# Altium schematic sheet MOTOR_Cu.SchDoc(MOTOR_Cu)
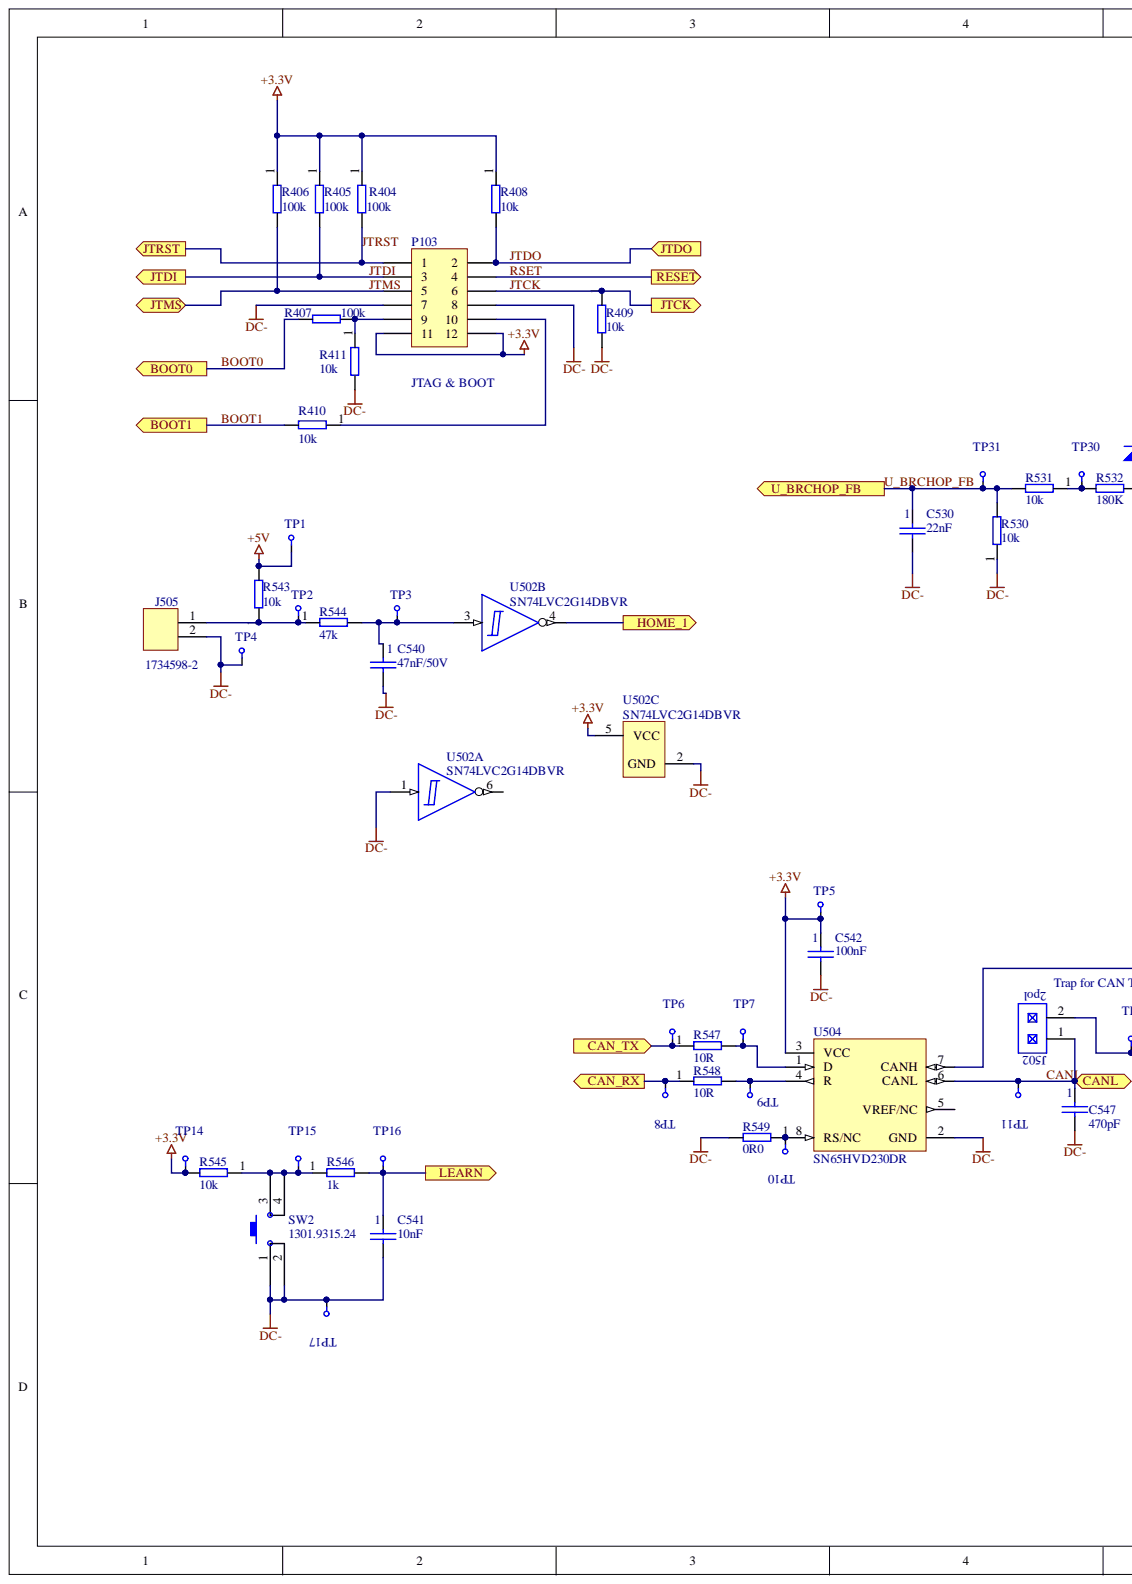
[diagram p0: MOTOR_Cu.SchDoc, left]
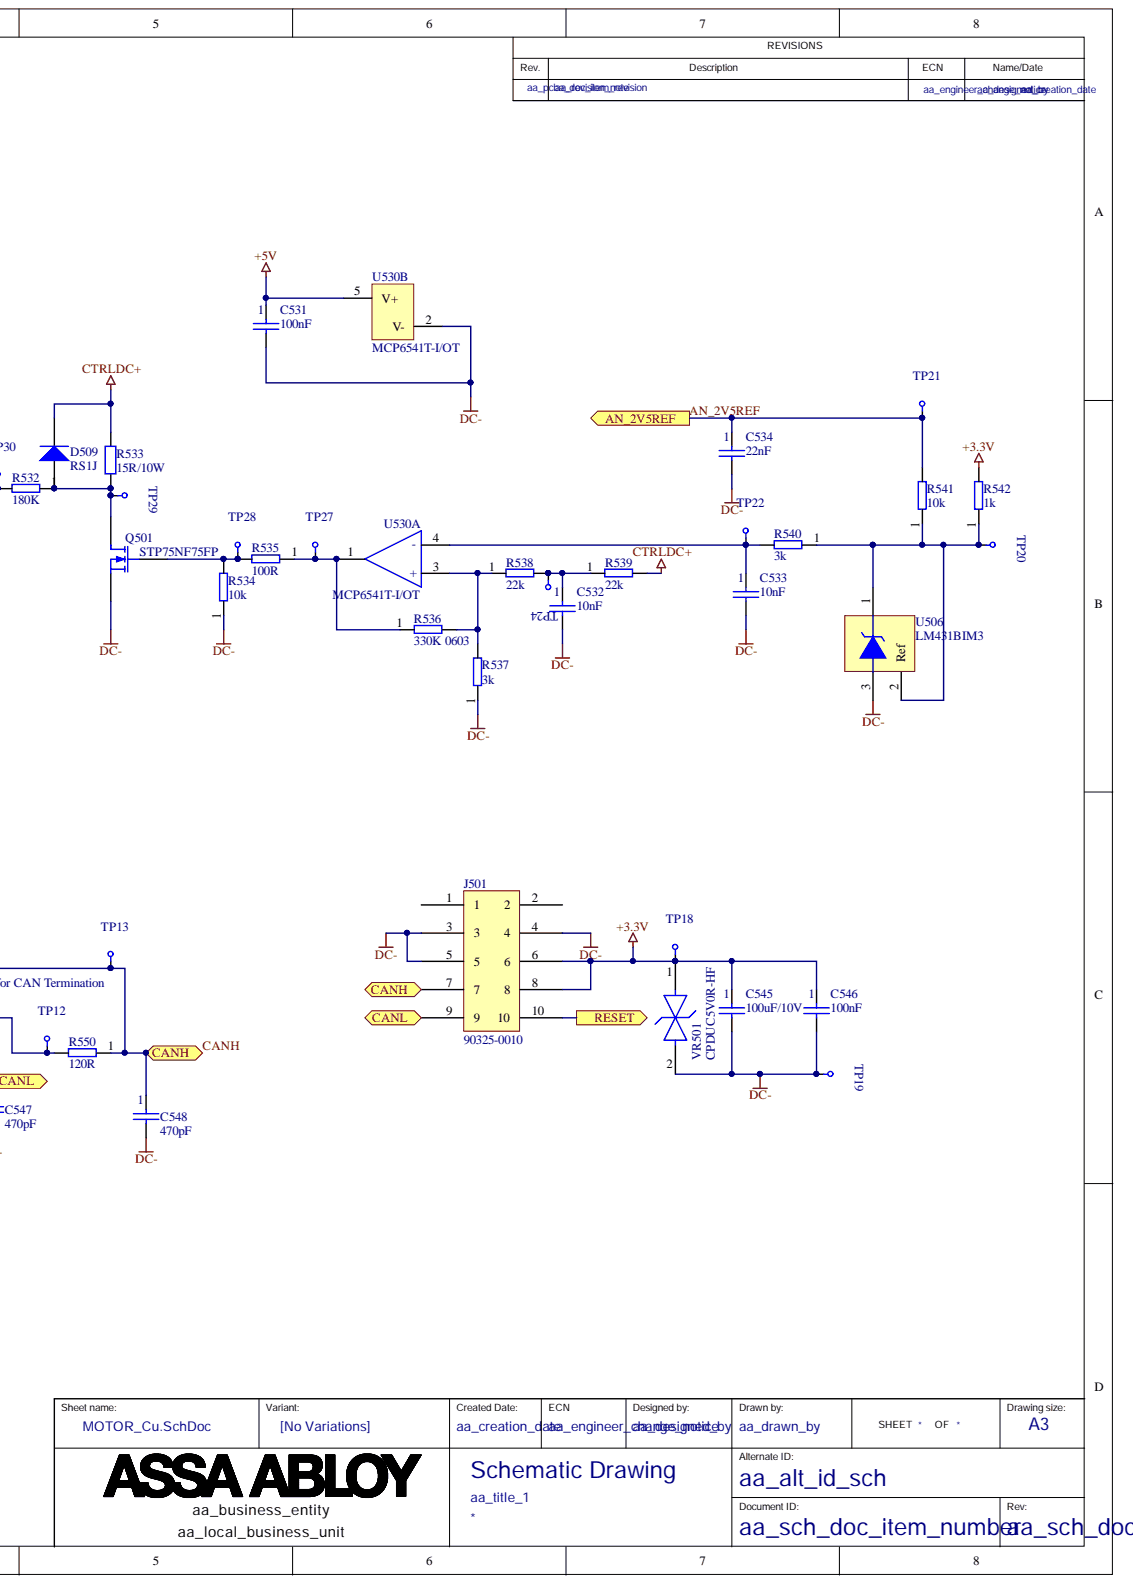
[diagram p1: MOTOR_Cu.SchDoc, right]

## Parts
C530  22nF  [CAPC1608X08N]
C531  100nF  [CAPC1608X08N]
C532  10nF  [CAPC1608X08N]
C533  10nF  [CAPC1608X08N]
C534  22nF  [CAPC1608X08N]
C540  47nF/50V  [CAPC1608X10N]
C541  10nF  [CAPC1608X08N]
C542  100nF  [CAPC1608X08N]
C545  100uF/10V  [CAPC3216X18N]
C546  100nF  [CAPC1608X08N]
C547  470pF  [CAPC1608X08N]
C548  470pF  [CAPC1608X08N]
D509  RS1J  [SMA-S1G]
J501  90325-0010  [MOLEX_90325-0010]
J502  2pol  [HDRV2W64P254_2X1_508X248H838]
J505  1734598-2  [CON1X2-MOLEX-0530470210]
P103  JTAG & BOOT  [TP_6X2]
Q501  STP75NF75FP  [MOSFET_STP75NF75FP]
R404  100k  [RESC1608X05N]
R405  100k  [RESC1608X05N]
R406  100k  [RESC1608X05N]
R407  100k  [RESC1608X05N]
R408  10k  [RESC1608X05N]
R409  10k  [RESC1608X05N]
R410  10k  [RESC1608X05N]
R411  10k  [RESC1608X05N]
R530  10k  [RESC1608X05N]
R531  10k  [RESC1608X05N]
R532  180K  [0603R/H]
R533  15R/10W  [RES_POWER_36x16x11.5]
R534  10k  [RESC1608X05N]
R535  100R  [RESC1608X05N]
R536  330K 0603  [0603R/H]
R537  3k  [RESC1608X05N]
R538  22k  [RESC1608X05N]
R539  22k  [RESC1608X05N]
R540  3k  [RESC1608X05N]
R541  10k  [RESC1608X05N]
R542  1k  [RESC1608X05N]
R543  10k  [RESC1608X05N]
R544  47k  [RESC1608X05N]
R545  10k  [RESC1608X05N]
R546  1k  [RESC1608X05N]
R547  10R  [RESC1608X05N]
R548  10R  [RESC1608X05N]
R549  0R0  [RESC1608X05N]
R550  120R  [RESC2012X07N]
SW2  1301.9315.24  [SW_SCHURTER_1301.9315.24]
TP1  Testpoint SMD  [PCB_PARTS_TESTPOINT100_SMD]  (value not drawn)  (no BOM)
TP2  Testpoint SMD  [PCB_PARTS_TESTPOINT100_SMD]  (value not drawn)  (no BOM)
TP3  Testpoint SMD  [PCB_PARTS_TESTPOINT100_SMD]  (value not drawn)  (no BOM)
TP4  Testpoint SMD  [PCB_PARTS_TESTPOINT100_SMD]  (value not drawn)  (no BOM)
TP5  Testpoint SMD  [PCB_PARTS_TESTPOINT100_SMD]  (value not drawn)  (no BOM)
TP6  Testpoint SMD  [PCB_PARTS_TESTPOINT100_SMD]  (value not drawn)  (no BOM)
TP7  Testpoint SMD  [PCB_PARTS_TESTPOINT100_SMD]  (value not drawn)  (no BOM)
TP8  Testpoint SMD  [PCB_PARTS_TESTPOINT100_SMD]  (value not drawn)  (no BOM)
TP9  Testpoint SMD  [PCB_PARTS_TESTPOINT100_SMD]  (value not drawn)  (no BOM)
TP10  Testpoint SMD  [PCB_PARTS_TESTPOINT100_SMD]  (value not drawn)  (no BOM)
TP11  Testpoint SMD  [PCB_PARTS_TESTPOINT100_SMD]  (value not drawn)  (no BOM)
TP12  Testpoint SMD  [PCB_PARTS_TESTPOINT100_SMD]  (value not drawn)  (no BOM)
TP13  Testpoint SMD  [PCB_PARTS_TESTPOINT100_SMD]  (value not drawn)  (no BOM)
TP14  Testpoint SMD  [PCB_PARTS_TESTPOINT100_SMD]  (value not drawn)  (no BOM)
TP15  Testpoint SMD  [PCB_PARTS_TESTPOINT100_SMD]  (value not drawn)  (no BOM)
TP16  Testpoint SMD  [PCB_PARTS_TESTPOINT100_SMD]  (value not drawn)  (no BOM)
TP17  Testpoint SMD  [PCB_PARTS_TESTPOINT100_SMD]  (value not drawn)  (no BOM)
TP18  Testpoint SMD  [PCB_PARTS_TESTPOINT100_SMD]  (value not drawn)  (no BOM)
TP19  Testpoint SMD  [PCB_PARTS_TESTPOINT100_SMD]  (value not drawn)  (no BOM)
TP20  Testpoint SMD  [PCB_PARTS_TESTPOINT100_SMD]  (value not drawn)  (no BOM)
TP21  Testpoint SMD  [PCB_PARTS_TESTPOINT100_SMD]  (value not drawn)  (no BOM)
TP22  Testpoint SMD  [PCB_PARTS_TESTPOINT100_SMD]  (value not drawn)  (no BOM)
TP24  Testpoint SMD  [PCB_PARTS_TESTPOINT100_SMD]  (value not drawn)  (no BOM)
TP27  Testpoint SMD  [PCB_PARTS_TESTPOINT100_SMD]  (value not drawn)  (no BOM)
TP28  Testpoint SMD  [PCB_PARTS_TESTPOINT100_SMD]  (value not drawn)  (no BOM)
TP29  Testpoint SMD  [PCB_PARTS_TESTPOINT100_SMD]  (value not drawn)  (no BOM)
TP30  Testpoint SMD  [PCB_PARTS_TESTPOINT100_SMD]  (value not drawn)  (no BOM)
TP31  Testpoint SMD  [PCB_PARTS_TESTPOINT100_SMD]  (value not drawn)  (no BOM)
U502  SN74LVC2G14DBVR  [SOT95P280X145-6N]  (parts A,B,C)
U504  SN65HVD230DR  [SOIC127P600X175-8N]
U506  LM431BIM3  [SOT95P251X112-3N]
U530  MCP6541T-I/OT  [SOT95P280X145-5N]  (parts A,B)
VR501  CPDUC5V0R-HF  [DFN110P170X55-2N]

## Ports
AN_2V5REF, BOOT0, BOOT1, CAN_RX, CAN_TX, CANH, CANL, HOME_1, JTCK, JTDI, JTDO, JTMS, JTRST, LEARN, RESET, U_BRCHOP_FB

## Nets
+3.3V: C542.1 C545.1 C546.1 J501.6 J501.8 P103.11 P103.12 R404.1 R405.1 R406.1 R408.1 R542.2 R545.2 TP5.1 TP14.1 TP18.1 U502.5(VCC) U504.3(VCC) VR501.1
+5V: C531.1 R543.2 TP1.1 U530.5(V+)
CTRLDC+: D509.2 R533.1 R539.2
DC-: C530.2 C531.2 C532.2 C533.2 C534.2 C540.2 C541.2 C542.2 C545.2 C546.2 C547.2 C548.2 J501.3 J501.4 J501.5 J505.2 P103.7 P103.8 Q501.3 R409.2 R411.2 R530.1 R534.1 R537.1 R549.2 SW2.1 SW2.2 TP4.1 TP17.1 TP19.1 U502.1 U502.2(GND) U504.2(GND) U506.3 U530.2(V-) VR501.2
AN_2V5REF: C534.1 R541.2 TP21.1 ; port AN_2V5REF
BOOT0: R407.1 ; port BOOT0
BOOT1: R410.2 ; port BOOT1
CAN_RX: R548.1 TP8.1 ; port CAN_RX
CAN_TX: R547.1 TP6.1 ; port CAN_TX
CANH: C548.1 J501.7 R550.1 TP13.1 U504.7(CANH) ; port CANH
CANL: C547.1 J501.9 J502.1 TP11.1 U504.6(CANL) ; port CANL
HOME_1: U502.4 ; port HOME_1
JTCK: P103.6 R409.1 ; port JTCK
JTDI: P103.3 R405.2 ; port JTDI
JTDO: P103.2 R408.2 ; port JTDO
JTMS: P103.5 R406.2 ; port JTMS
JTRST: P103.1 R404.2 ; port JTRST
LEARN: C541.1 R546.2 TP16.1 ; port LEARN
RSET: J501.10 P103.4 ; port RESET
U_BRCHOP_FB: C530.1 R530.2 R531.2 TP31.1 ; port U_BRCHOP_FB
NetC532_1: C532.1 R538.2 R539.1 TP24.1
NetC533_1: C533.1 R540.2 TP22.1 U530.4(-)
NetC540_1: C540.1 R544.2 TP3.1 U502.3
NetD509_1: D509.1 Q501.2 R532.1 R533.2 TP29.1
NetJ502_2: J502.2 R550.2 TP12.1
NetJ505_1: J505.1 R543.1 R544.1 TP2.1
NetP103_9: P103.9 R407.2 R411.1
NetP103_10: P103.10 R410.1
NetQ501_1: Q501.1 R534.2 R535.2 TP28.1
NetR531_1: R531.1 R532.2 TP30.1
NetR535_1: R535.1 R536.1 TP27.1 U530.1
NetR536_2: R536.2 R537.2 R538.1 U530.3(+)
NetR540_1: R540.1 R541.1 R542.1 TP20.1 U506.1 U506.2
NetR545_1: R545.1 R546.1 SW2.3 SW2.4 TP15.1
NetR547_2: R547.2 TP7.1 U504.1(D)
NetR548_2: R548.2 TP9.1 U504.4(R)
NetR549_1: R549.1 TP10.1 U504.8(RS/NC)

Not connected: J501 1 2; U502 6; U504 5(VREF/NC)

## Library data of the parts
C530 C534 = 715500: Capacitor; APPROVED_MPN_04: 2238586_5641 (RoHS); APPROVED_MPN_03: GRM188R71H223KA01_ (RoHS); APPROVED_MPN_02: C0603C223K5RAC (RoHS); APPROVED_MPN_01: 06035C223KAT2A (RoHS); APPROVED_MANUFACTURER_04: Yageo Phycomp.; APPROVED_MANUFACTURER_03: MURATA; APPROVED_MANUFACTURER_02: KEMET; APPROVED_MANUFACTURER_01: AVX; PHYSICALPACKAGE: EIA0603; MOUNTEDTYPE: SMD; Database Table Name: Capacitors_Ceramic; VALUE: 22nF; TOLERANCE: -; PROTOTYPE_MPN_01: -; PROTOTYPE_MANUFACTURER_01: -; POWERRATING: -; PARTNUMBER: 715500; PARTNAME: Capacitor; Library Name: AltiumLib_Database.DbLib; Component Kind: Standard; APPROVED_MPN_08: -; APPROVED_MPN_07: -; APPROVED_MPN_06: -; APPROVED_MPN_05: -; APPROVED_MANUFACTURER_08: -; APPROVED_MANUFACTURER_07: -; APPROVED_MANUFACTURER_06: -; APPROVED_MANUFACTURER_05: -
C531 C546 = 715219: Capacitor; APPROVED_MPN_05: 223878615649 ,X7R, 0603, 10%; APPROVED_MPN_04: CC0603KRX7R7BB104; APPROVED_MPN_03: 0603YC104KAT ,X7R,0603,10%; APPROVED_MPN_02: GRM188R71C104KA01; APPROVED_MPN_01: CL10B104KO8NNN; APPROVED_MANUFACTURER_05: Yageo Phy; APPROVED_MANUFACTURER_04: YAGEO; APPROVED_MANUFACTURER_03: AVX KYOCERA; APPROVED_MANUFACTURER_02: MURATA; APPROVED_MANUFACTURER_01: SAMSUNG; PHYSICALPACKAGE: EIA0603; MOUNTEDTYPE: SMD; Database Table Name: Capacitors_Ceramic; VALUE: 100nF; TOLERANCE: -; PROTOTYPE_MPN_01: -; PROTOTYPE_MANUFACTURER_01: -; POWERRATING: -; PARTNUMBER: 715219; PARTNAME: Capacitor; Library Name: AltiumLib_Database.DbLib; Component Kind: Standard; APPROVED_MPN_08: -; APPROVED_MPN_07: -; APPROVED_MPN_06: -; APPROVED_MANUFACTURER_08: -; APPROVED_MANUFACTURER_07: -; APPROVED_MANUFACTURER_06: -
C532 C533 C541 = 1700542: Capacitor; APPROVED_MPN_01: C1608CH1E103J  RoHS; APPROVED_MANUFACTURER_01: TDK; PHYSICALPACKAGE: EIA0603; MOUNTEDTYPE: SMD; Database Table Name: Capacitors_Ceramic; VALUE: 10nF; TOLERANCE: -; PROTOTYPE_MPN_01: -; PROTOTYPE_MANUFACTURER_01: -; POWERRATING: -; PARTNUMBER: 1700542; PARTNAME: Capacitor; Library Name: AltiumLib_Database.DbLib; Component Kind: Standard; APPROVED_MPN_08: -; APPROVED_MPN_07: -; APPROVED_MPN_06: -; APPROVED_MPN_05: -; APPROVED_MPN_04: -; APPROVED_MPN_03: -; APPROVED_MPN_02: -; APPROVED_MANUFACTURER_08: -; APPROVED_MANUFACTURER_07: -; APPROVED_MANUFACTURER_06: -; APPROVED_MANUFACTURER_05: -; APPROVED_MANUFACTURER_04: -; APPROVED_MANUFACTURER_03: -; APPROVED_MANUFACTURER_02: -
C540 = 1700539: Capacitor; APPROVED_MPN_02: CC0603KRX7R9BB473; APPROVED_MPN_01: C1608X7R1H473K080AA; APPROVED_MANUFACTURER_02: YAGEO; APPROVED_MANUFACTURER_01: TDK; TOLERANCE: 10%; PHYSICALPACKAGE: EIA0603; MOUNTEDTYPE: SMD; Database Table Name: Capacitors_Ceramic; VALUE: 47nF/50V; PROTOTYPE_MPN_01: -; PROTOTYPE_MANUFACTURER_01: -; POWERRATING: -; PARTNUMBER: 1700539; PARTNAME: Capacitor; Library Name: AltiumLib_Database.DbLib; Component Kind: Standard; APPROVED_MPN_08: -; APPROVED_MPN_07: -; APPROVED_MPN_06: -; APPROVED_MPN_05: -; APPROVED_MPN_04: -; APPROVED_MPN_03: -; APPROVED_MANUFACTURER_08: -; APPROVED_MANUFACTURER_07: -; APPROVED_MANUFACTURER_06: -; APPROVED_MANUFACTURER_05: -; APPROVED_MANUFACTURER_04: -; APPROVED_MANUFACTURER_03: -
C542 = 1700540: Capacitor; APPROVED_MPN_06: 0603B104K500CT; APPROVED_MPN_05: CC0603K_9104; APPROVED_MPN_04: C0603C104K5RAC; APPROVED_MPN_03: 06035C104KAT_A; APPROVED_MPN_02: GRM188R71H104K; APPROVED_MPN_01: C1608X7R1H104K; APPROVED_MANUFACTURER_06: WALSIN; APPROVED_MANUFACTURER_05: YAGEO; APPROVED_MANUFACTURER_04: KEMET; APPROVED_MANUFACTURER_03: AVX; APPROVED_MANUFACTURER_02: MURATA; APPROVED_MANUFACTURER_01: TDK; PHYSICALPACKAGE: EIA0603; MOUNTEDTYPE: SMD; Database Table Name: Capacitors_Ceramic; VALUE: 100nF; TOLERANCE: -; PROTOTYPE_MPN_01: -; PROTOTYPE_MANUFACTURER_01: -; POWERRATING: -; PARTNUMBER: 1700540; PARTNAME: Capacitor; Library Name: AltiumLib_Database.DbLib; Component Kind: Standard; APPROVED_MPN_08: -; APPROVED_MPN_07: -; APPROVED_MANUFACTURER_08: -; APPROVED_MANUFACTURER_07: -
C545 = 1703746: Capacitor; APPROVED_MPN_02: C3216X5R1A107M160AC; APPROVED_MPN_01: GRM31CR61A107ME05L; APPROVED_MANUFACTURER_02: TDK; APPROVED_MANUFACTURER_01: Murata; TOLERANCE: 20%; PHYSICALPACKAGE: EIA1206; MOUNTEDTYPE: SMD; Database Table Name: Capacitors_Ceramic; VALUE: 100uF/10V; PROTOTYPE_MPN_01: -; PROTOTYPE_MANUFACTURER_01: -; POWERRATING: -; PARTNUMBER: 1703746; PARTNAME: Capacitor; Library Name: AltiumLib_Database.DbLib; Component Kind: Standard; APPROVED_MPN_08: -; APPROVED_MPN_07: -; APPROVED_MPN_06: -; APPROVED_MPN_05: -; APPROVED_MPN_04: -; APPROVED_MPN_03: -; APPROVED_MANUFACTURER_08: -; APPROVED_MANUFACTURER_07: -; APPROVED_MANUFACTURER_06: -; APPROVED_MANUFACTURER_05: -; APPROVED_MANUFACTURER_04: -; APPROVED_MANUFACTURER_03: -
C547 C548 = 1700536: Capacitor; APPROVED_MPN_01: C1608JB1H471K  RoHS; APPROVED_MANUFACTURER_01: TDK; PHYSICALPACKAGE: EIA0603; MOUNTEDTYPE: SMD; Database Table Name: Capacitors_Ceramic; VALUE: 470pF; TOLERANCE: -; PROTOTYPE_MPN_01: -; PROTOTYPE_MANUFACTURER_01: -; POWERRATING: -; PARTNUMBER: 1700536; PARTNAME: Capacitor; Library Name: AltiumLib_Database.DbLib; Component Kind: Standard; APPROVED_MPN_08: -; APPROVED_MPN_07: -; APPROVED_MPN_06: -; APPROVED_MPN_05: -; APPROVED_MPN_04: -; APPROVED_MPN_03: -; APPROVED_MPN_02: -; APPROVED_MANUFACTURER_08: -; APPROVED_MANUFACTURER_07: -; APPROVED_MANUFACTURER_06: -; APPROVED_MANUFACTURER_05: -; APPROVED_MANUFACTURER_04: -; APPROVED_MANUFACTURER_03: -; APPROVED_MANUFACTURER_02: -
D509 = Diode: Default Diode; MPN: Vishay, Fairchild, Taiwan Semiconductor/ RS1J (RoHS); Article: 1700744-2.0; Simulation: DIODE; Signal Integrity: Diode; Published: 8-Jun-2000; PackageVersion: Sep-1996; PackageReference: SMC; PackageDescription: DO-214-AB/SMC; 2 C-Bend Leads; Body 7.9 x 5.9 mm, inc. leads (LxW); Library Name: Miscellaneous Devices.IntLib; LatestRevisionNote: Re-released for DXP Platform.; LatestRevisionDate: 17-Jul-2002; Component Kind: Standard; Code_JEDEC: DO-214-AB; Publisher: Altium Limited
J501 = 1703717: 10 pins connector; APPROVED_MANUFACTURER_01: Molex; PHYSICALPACKAGE: 2x5pol 1.27mm; MOUNTEDTYPE: THM; Database Table Name: CONNECTORS; VALUE: 90325-0010; TOLERANCE: -; PROTOTYPE_MPN_01: -; PROTOTYPE_MANUFACTURER_01: -; POWERRATING: -; PARTNUMBER: 1703717; PARTNAME: 90325-0010; Library Name: AltiumLib_Database.DbLib; Component Kind: Standard; APPROVED_MPN_08: -; APPROVED_MPN_07: -; APPROVED_MPN_06: -; APPROVED_MPN_05: -; APPROVED_MPN_04: -; APPROVED_MPN_03: -; APPROVED_MPN_02: -; APPROVED_MPN_01: 90325-0010; APPROVED_MANUFACTURER_08: -; APPROVED_MANUFACTURER_07: -; APPROVED_MANUFACTURER_06: -; APPROVED_MANUFACTURER_05: -; APPROVED_MANUFACTURER_04: -; APPROVED_MANUFACTURER_03: -; APPROVED_MANUFACTURER_02: -
J502 = 1700830: 2pol connector; APPROVED_MPN_02: 1125-1102GOS11201; APPROVED_MPN_01: 90120-0922; APPROVED_MANUFACTURER_02: WCON; APPROVED_MANUFACTURER_01: Molex; PHYSICALPACKAGE: 2pol 2.54mm; MOUNTEDTYPE: THM; Database Table Name: CONNECTORS; VALUE: 2pol; TOLERANCE: -; PROTOTYPE_MPN_01: -; PROTOTYPE_MANUFACTURER_01: -; POWERRATING: -; PARTNUMBER: 1700830; PARTNAME: 2pol; Library Name: AltiumLib_Database.DbLib; Component Kind: Standard; APPROVED_MPN_08: -; APPROVED_MPN_07: -; APPROVED_MPN_06: -; APPROVED_MPN_05: -; APPROVED_MPN_04: -; APPROVED_MPN_03: -; APPROVED_MANUFACTURER_08: -; APPROVED_MANUFACTURER_07: -; APPROVED_MANUFACTURER_06: -; APPROVED_MANUFACTURER_05: -; APPROVED_MANUFACTURER_04: -; APPROVED_MANUFACTURER_03: -
J505 = 1701462: 2-pol connector; APPROVED_MPN_02: 1251SM-02; APPROVED_MANUFACTURER_02: NELTRON; APPROVED_MANUFACTURER_01: Tyco; PHYSICALPACKAGE: 1x2pol 1.25mm; MOUNTEDTYPE: THM; Database Table Name: CONNECTORS; VALUE: 1734598-2; TOLERANCE: -; PROTOTYPE_MPN_01: -; PROTOTYPE_MANUFACTURER_01: -; POWERRATING: -; PARTNUMBER: 1701462; PARTNAME: 1734598-2; Library Name: AltiumLib_Database.DbLib; Component Kind: Standard; APPROVED_MPN_08: -; APPROVED_MPN_07: -; APPROVED_MPN_06: -; APPROVED_MPN_05: -; APPROVED_MPN_04: -; APPROVED_MPN_03: -; APPROVED_MPN_01: 1734598-2; APPROVED_MANUFACTURER_08: -; APPROVED_MANUFACTURER_07: -; APPROVED_MANUFACTURER_06: -; APPROVED_MANUFACTURER_05: -; APPROVED_MANUFACTURER_04: -; APPROVED_MANUFACTURER_03: -
P103 = Header 6X2: Header, 6-Pin, Dual row; MPN: Test Point 6x2; Signal Integrity: Connector; Library Name: Miscellaneous Connectors.IntLib; LatestRevisionNote: Re-released for DXP Platform.; LatestRevisionDate: 17-Jul-2002; Component Kind: Standard; Publisher: Altium Limited
Q501 = MOSFET-N_STP75NF75FP: N-Channel MOSFET; MPN: International Rectifier / IRFIZ48N, IRFI1010, IRFI3205; STMicroelectronics / STP75NF75FP, STP60NF06 (RoHS); Article: 1701449-2.0/1701807-1.0/715320-5.0; Simulation: NMOS; Published: 8-Jun-2000; PackageVersion: 1995/1996; PackageReference: E3; PackageDescription: TO, Flat Index; 3 In-Line, Axial Leads; Body Dia. 4.6mm; Lead Dia. 0.5 mm (max); Library Name: MCU_Sch_Lib.SchLib; LatestRevisionNote: Re-released for DXP Platform.; LatestRevisionDate: 17-Jul-2002; Component Kind: Standard; Publisher: Altium Limited
R404-R407 = 714835: Resistor; APPROVED_MPN_05: MCR03-X-F-100k (RoHS); APPROVED_MPN_04: RK73H1JTTD100kF (RoHS); APPROVED_MPN_03: RC22,2322704X11004L (RoHS); APPROVED_MPN_02: MCT0603-50,1%,100k ET_ E3 (RoHS); APPROVED_MPN_01: D11, 100k/1% ET_ E3 (RoHS); APPROVED_MANUFACTURER_05: ROHM; APPROVED_MANUFACTURER_04: KOA; APPROVED_MANUFACTURER_03: Yageo; APPROVED_MANUFACTURER_02: Vishay; TOLERANCE: 1%; POWERRATING: 0.063W; PHYSICALPACKAGE: EIA0603; MOUNTEDTYPE: SMD; Database Table Name: Resistors; VALUE: 100k; PROTOTYPE_MPN_01: -; PROTOTYPE_MANUFACTURER_01: -; PARTNUMBER: 714835; PARTNAME: 100k; Library Name: AltiumLib_Database.DbLib; Component Kind: Standard; APPROVED_MPN_08: -; APPROVED_MPN_07: -; APPROVED_MPN_06: -; APPROVED_MANUFACTURER_08: -; APPROVED_MANUFACTURER_07: -; APPROVED_MANUFACTURER_06: -; APPROVED_MANUFACTURER_01: Vishay
R408-R411 R530 R531 R534 R541 R543 R545 = 714811: Resistor; APPROVED_MPN_05: MCR03-X-F-10k (RoHS); APPROVED_MPN_04: RK73H1JTTD10kF (RoHS); APPROVED_MPN_03: RC22 ,2322704X11003L (RoHS); APPROVED_MPN_02: MCT0603-50,1%,10k ET_ E3 (RoHS); APPROVED_MPN_01: D11, 10k/1% ET_ E3 (RoHS); APPROVED_MANUFACTURER_05: ROHM; APPROVED_MANUFACTURER_04: KOA; APPROVED_MANUFACTURER_03: Yageo; APPROVED_MANUFACTURER_02: Vishay; TOLERANCE: 1%; POWERRATING: 0.063W; PHYSICALPACKAGE: EIA0603; MOUNTEDTYPE: SMD; Database Table Name: Resistors; VALUE: 10k; PROTOTYPE_MPN_01: -; PROTOTYPE_MANUFACTURER_01: -; PARTNUMBER: 714811; PARTNAME: 10k; Library Name: AltiumLib_Database.DbLib; Component Kind: Standard; APPROVED_MPN_08: -; APPROVED_MPN_07: -; APPROVED_MPN_06: -; APPROVED_MANUFACTURER_08: -; APPROVED_MANUFACTURER_07: -; APPROVED_MANUFACTURER_06: -; APPROVED_MANUFACTURER_01: Vishay
R532 R536 = Resistor: Library Name: Resistor.SchLib; Description: Resistor; Component Kind: Standard
R533 = Res2: Resistor; MPN: CCO-Chianchia: CRM10W15RJ; Value: 15R/10W; Simulation: RESISTOR; Signal Integrity: Res; Published: 8-Jun-2000; PackageReference: AXIAL-0.4; PackageDescription: Axial Device, Thru-Hole; 2 Leads; 0.4 in Pin Spacing; Library Name: MCU_Sch_Lib.SchLib; LatestRevisionNote: Re-released for DXP Platform.; LatestRevisionDate: 17-Jul-2002; Component Kind: Standard; Publisher: Altium Limited
R535 = 714764: Resistor; APPROVED_MPN_05: MCT0603-50,1%,100R; APPROVED_MPN_04: MCR03-X-F-100R; APPROVED_MPN_03: RK73H1JTD100RF; APPROVED_MPN_02: RC22H,2322704X11001; APPROVED_MPN_01: D11, 100R/1%; APPROVED_MANUFACTURER_05: BEYSCHLAG; APPROVED_MANUFACTURER_04: ROHM; APPROVED_MANUFACTURER_03: KOA; APPROVED_MANUFACTURER_02: PHILIPS; APPROVED_MANUFACTURER_01: OKAB ROE; TOLERANCE: 1%; POWERRATING: 0.063W; PHYSICALPACKAGE: EIA0603; MOUNTEDTYPE: SMD; Database Table Name: Resistors; VALUE: 100R; PROTOTYPE_MPN_01: -; PROTOTYPE_MANUFACTURER_01: -; PARTNUMBER: 714764; PARTNAME: 100R; Library Name: AltiumLib_Database.DbLib; Component Kind: Standard; APPROVED_MPN_08: -; APPROVED_MPN_07: -; APPROVED_MPN_06: -; APPROVED_MANUFACTURER_08: -; APPROVED_MANUFACTURER_07: -; APPROVED_MANUFACTURER_06: -
R537 R540 = 714798: Resistor; APPROVED_MPN_05: MCT0603-50,1%,3k (RoHS); APPROVED_MPN_04: MCR03-X-F-3k (RoHS); APPROVED_MPN_03: RK73H1JTTD3kF (RoHS); APPROVED_MPN_02: RC22H,2322704X3002L (RoHS); APPROVED_MPN_01: D11, 3k/1% ET_ E3 (RoHS); APPROVED_MANUFACTURER_05: BEYSCHLAG; APPROVED_MANUFACTURER_04: ROHM; APPROVED_MANUFACTURER_03: KOA; APPROVED_MANUFACTURER_02: Yageo; APPROVED_MANUFACTURER_01: OKAB ROE; TOLERANCE: 1%; POWERRATING: 0.063W; PHYSICALPACKAGE: EIA0603; MOUNTEDTYPE: SMD; Database Table Name: Resistors; VALUE: 3k; PROTOTYPE_MPN_01: -; PROTOTYPE_MANUFACTURER_01: -; PARTNUMBER: 714798; PARTNAME: 3k; Library Name: AltiumLib_Database.DbLib; Component Kind: Standard; APPROVED_MPN_08: -; APPROVED_MPN_07: -; APPROVED_MPN_06: -; APPROVED_MANUFACTURER_08: -; APPROVED_MANUFACTURER_07: -; APPROVED_MANUFACTURER_06: -
R538 R539 = 714819: Resistor; APPROVED_MPN_05: MCT0603-50,1%,22k; APPROVED_MPN_04: MCR03-X-F-22k; APPROVED_MPN_03: RK73H1JTD22kF; APPROVED_MPN_02: RC22H,2322704X12203; APPROVED_MPN_01: D11, 22k/1%; APPROVED_MANUFACTURER_05: BEYSCHLAG; APPROVED_MANUFACTURER_04: ROHM; APPROVED_MANUFACTURER_03: KOA; APPROVED_MANUFACTURER_02: PHILIPS; APPROVED_MANUFACTURER_01: OKAB ROE; TOLERANCE: 1%; POWERRATING: 0.063W; PHYSICALPACKAGE: EIA0603; MOUNTEDTYPE: SMD; Database Table Name: Resistors; VALUE: 22k; PROTOTYPE_MPN_01: -; PROTOTYPE_MANUFACTURER_01: -; PARTNUMBER: 714819; PARTNAME: 22k; Library Name: AltiumLib_Database.DbLib; Component Kind: Standard; APPROVED_MPN_08: -; APPROVED_MPN_07: -; APPROVED_MPN_06: -; APPROVED_MANUFACTURER_08: -; APPROVED_MANUFACTURER_07: -; APPROVED_MANUFACTURER_06: -
R542 R546 = 714787: Resistor; APPROVED_MPN_05: MCR03-X-F-1k (RoHS); APPROVED_MPN_04: RK73H1JTTD1kF (RoHS); APPROVED_MPN_03: RC22, 2322704X11002L (RoHS); APPROVED_MPN_02: MCT0603-50,1%,1k ET_ E3 (RoHS); APPROVED_MPN_01: D11, 1k/1%, ET_ E3(RoHS); APPROVED_MANUFACTURER_05: ROHM; APPROVED_MANUFACTURER_04: KOA; APPROVED_MANUFACTURER_03: Yageo; APPROVED_MANUFACTURER_02: BEYSCHLAG; APPROVED_MANUFACTURER_01: Vishay; TOLERANCE: 1%; POWERRATING: 0.063W; PHYSICALPACKAGE: EIA0603; MOUNTEDTYPE: SMD; Database Table Name: Resistors; VALUE: 1k; PROTOTYPE_MPN_01: -; PROTOTYPE_MANUFACTURER_01: -; PARTNUMBER: 714787; PARTNAME: 1k; Library Name: AltiumLib_Database.DbLib; Component Kind: Standard; APPROVED_MPN_08: -; APPROVED_MPN_07: -; APPROVED_MPN_06: -; APPROVED_MANUFACTURER_08: -; APPROVED_MANUFACTURER_07: -; APPROVED_MANUFACTURER_06: -
R544 = 714827: Resistor; APPROVED_MPN_05: MCT0603-50,1%,47k Lead Free (RoHS); APPROVED_MPN_04: MCR03-X-F-47k (RoHS); APPROVED_MPN_03: RK73H1JTTD47kF (RoHS); APPROVED_MPN_02: RC22H,2322704X4703L (RoHS); APPROVED_MPN_01: D11, 47k/1% ET_ E3 (RoHS); APPROVED_MANUFACTURER_05: BEYSCHLAG; APPROVED_MANUFACTURER_04: ROHM; APPROVED_MANUFACTURER_03: KOA; APPROVED_MANUFACTURER_02: Yageo; APPROVED_MANUFACTURER_01: OKAB ROE; TOLERANCE: 1%; POWERRATING: 0.063W; PHYSICALPACKAGE: EIA0603; MOUNTEDTYPE: SMD; Database Table Name: Resistors; VALUE: 47k; PROTOTYPE_MPN_01: -; PROTOTYPE_MANUFACTURER_01: -; PARTNUMBER: 714827; PARTNAME: 47k; Library Name: AltiumLib_Database.DbLib; Component Kind: Standard; APPROVED_MPN_08: -; APPROVED_MPN_07: -; APPROVED_MPN_06: -; APPROVED_MANUFACTURER_08: -; APPROVED_MANUFACTURER_07: -; APPROVED_MANUFACTURER_06: -
R547 R548 = 714740: Resistor; APPROVED_MPN_05: MCR03-X-F-10R (RoHS); APPROVED_MPN_04: RK73H1JTTD10RF (RoHS); APPROVED_MPN_03: RC22 ,2322704X1009L (RoHS); APPROVED_MPN_02: MCT0603-50,1%,10R, Lead Free (RoHS); APPROVED_MPN_01: D11, 10R/1% ET_ E3 (ROHS); APPROVED_MANUFACTURER_05: ROHM; APPROVED_MANUFACTURER_04: KOA; APPROVED_MANUFACTURER_03: Yageo Phycomp; APPROVED_MANUFACTURER_02: Vishay; TOLERANCE: 1%; POWERRATING: 0.063W; PHYSICALPACKAGE: EIA0603; MOUNTEDTYPE: SMD; Database Table Name: Resistors; VALUE: 10R; PROTOTYPE_MPN_01: -; PROTOTYPE_MANUFACTURER_01: -; PARTNUMBER: 714740; PARTNAME: 10R; Library Name: AltiumLib_Database.DbLib; Component Kind: Standard; APPROVED_MPN_08: -; APPROVED_MPN_07: -; APPROVED_MPN_06: -; APPROVED_MANUFACTURER_08: -; APPROVED_MANUFACTURER_07: -; APPROVED_MANUFACTURER_06: -; APPROVED_MANUFACTURER_01: Vishay
R549 = 715204: Resistor; APPROVED_MPN_05: MCR03-X-F-X-0R0; APPROVED_MPN_04: RK73H1JTD0R0F; APPROVED_MPN_03: RC21,2322702X0006; APPROVED_MPN_02: OCR0603 0R0F; APPROVED_MPN_01: D11, 0R0/1%; APPROVED_MANUFACTURER_05: ROHM; APPROVED_MANUFACTURER_04: KOA; APPROVED_MANUFACTURER_03: PHILIPS; APPROVED_MANUFACTURER_02: DRALORIC; APPROVED_MANUFACTURER_01: ROEDERSTEIN; PHYSICALPACKAGE: EIA0603; MOUNTEDTYPE: SMD; Database Table Name: Resistors; VALUE: 0R0; TOLERANCE: -; PROTOTYPE_MPN_01: -; PROTOTYPE_MANUFACTURER_01: -; POWERRATING: -; PARTNUMBER: 715204; PARTNAME: RESISTOR; Library Name: AltiumLib_Database.DbLib; Component Kind: Standard; APPROVED_MPN_08: -; APPROVED_MPN_07: -; APPROVED_MPN_06: -; APPROVED_MANUFACTURER_08: -; APPROVED_MANUFACTURER_07: -; APPROVED_MANUFACTURER_06: -
R550 = 1700013: Resistor; PARTNAME: CRCW0805120RFKEA; APPROVED_MPN_04: RK73H2ATTD1200F, 120R, 1% (RoHS); APPROVED_MPN_03: RC12H, 120R, 1%, Lead Free (RoHS); APPROVED_MPN_02: CRCW0805, 120R, 1% ET_ E3 (RoHS); APPROVED_MPN_01: D12, 100, 120R, 1% ET1-E3 (RoHS); APPROVED_MANUFACTURER_04: KOA; APPROVED_MANUFACTURER_03: YAGEO; APPROVED_MANUFACTURER_02: VISHAY; PHYSICALPACKAGE: EIA0805; MOUNTEDTYPE: SMD; Database Table Name: Resistors; VALUE: 120R; TOLERANCE: -; PROTOTYPE_MPN_01: -; PROTOTYPE_MANUFACTURER_01: -; POWERRATING: -; PARTNUMBER: 1700013; Library Name: AltiumLib_Database.DbLib; Component Kind: Standard; APPROVED_MPN_08: -; APPROVED_MPN_07: -; APPROVED_MPN_06: -; APPROVED_MPN_05: -; APPROVED_MANUFACTURER_08: -; APPROVED_MANUFACTURER_07: -; APPROVED_MANUFACTURER_06: -; APPROVED_MANUFACTURER_05: -; APPROVED_MANUFACTURER_01: VISHAY
SW2 = 1701959: SPST NO SMD; APPROVED_MANUFACTURER_01: Schurter; PHYSICALPACKAGE: 10 x 6 mm; Database Table Name: Switches; VALUE: 1301.9315.24; TOLERANCE: -; PROTOTYPE_MPN_01: -; PROTOTYPE_MANUFACTURER_01: -; POWERRATING: -; PARTNUMBER: 1701959; PARTNAME: 1301.9315.24; MOUNTEDTYPE: SMD; Library Name: AltiumLib_Database.DbLib; Component Kind: Standard; APPROVED_MPN_08: -; APPROVED_MPN_07: -; APPROVED_MPN_06: -; APPROVED_MPN_05: -; APPROVED_MPN_04: -; APPROVED_MPN_03: -; APPROVED_MPN_02: -; APPROVED_MPN_01: 1301.9315.24; APPROVED_MANUFACTURER_08: -; APPROVED_MANUFACTURER_07: -; APPROVED_MANUFACTURER_06: -; APPROVED_MANUFACTURER_05: -; APPROVED_MANUFACTURER_04: -; APPROVED_MANUFACTURER_03: -; APPROVED_MANUFACTURER_02: -
TP1-TP22 TP24 TP27-TP31 = 1006: Testpoint; PHYSICALPACKAGE: 1mm SMD; Database Table Name: PCB_Parts; VALUE: Testpoint SMD; TOLERANCE: -; PROTOTYPE_MPN_01: -; PROTOTYPE_MANUFACTURER_01: -; POWERRATING: -; PARTNUMBER: 1006; PARTNAME: -; MOUNTEDTYPE: -; Library Name: AltiumLib_Database.DbLib; APPROVED_MPN_08: -; APPROVED_MPN_07: -; APPROVED_MPN_06: -; APPROVED_MPN_05: -; APPROVED_MPN_04: -; APPROVED_MPN_03: -; APPROVED_MPN_02: -; APPROVED_MPN_01: -; APPROVED_MANUFACTURER_08: -; APPROVED_MANUFACTURER_07: -; APPROVED_MANUFACTURER_06: -; APPROVED_MANUFACTURER_05: -; APPROVED_MANUFACTURER_04: -; APPROVED_MANUFACTURER_03: -; APPROVED_MANUFACTURER_02: -; APPROVED_MANUFACTURER_01: -
U502 = 1703057: Dual Schmitt-Trigger Inverter; APPROVED_MANUFACTURER_01: TI; PHYSICALPACKAGE: SOT23-6; MOUNTEDTYPE: SMD; Database Table Name: IC_Logic; VALUE: SN74LVC2G14DBVR; TOLERANCE: -; PROTOTYPE_MPN_01: -; PROTOTYPE_MANUFACTURER_01: -; POWERRATING: -; PARTNUMBER: 1703057; PARTNAME: SN74LVC2G14DBVR; Library Name: AltiumLib_Database.DbLib; Component Kind: Standard; APPROVED_MPN_08: -; APPROVED_MPN_07: -; APPROVED_MPN_06: -; APPROVED_MPN_05: -; APPROVED_MPN_04: -; APPROVED_MPN_03: -; APPROVED_MPN_02: -; APPROVED_MPN_01: SN74LVC2G14DBVR; APPROVED_MANUFACTURER_08: -; APPROVED_MANUFACTURER_07: -; APPROVED_MANUFACTURER_06: -; APPROVED_MANUFACTURER_05: -; APPROVED_MANUFACTURER_04: -; APPROVED_MANUFACTURER_03: -; APPROVED_MANUFACTURER_02: -
U504 = 1703056: CAN Transceiver; APPROVED_MANUFACTURER_01: TI; PHYSICALPACKAGE: SOIC-8; MOUNTEDTYPE: SMD; Database Table Name: IC_Interface; VALUE: SN65HVD230DR; TOLERANCE: -; PROTOTYPE_MPN_01: -; PROTOTYPE_MANUFACTURER_01: -; POWERRATING: -; PARTNUMBER: 1703056; PARTNAME: SN65HVD230DR; Library Name: AltiumLib_Database.DbLib; Component Kind: Standard; APPROVED_MPN_08: -; APPROVED_MPN_07: -; APPROVED_MPN_06: -; APPROVED_MPN_05: -; APPROVED_MPN_04: -; APPROVED_MPN_03: -; APPROVED_MPN_02: -; APPROVED_MPN_01: SN65HVD230DR; APPROVED_MANUFACTURER_08: -; APPROVED_MANUFACTURER_07: -; APPROVED_MANUFACTURER_06: -; APPROVED_MANUFACTURER_05: -; APPROVED_MANUFACTURER_04: -; APPROVED_MANUFACTURER_03: -; APPROVED_MANUFACTURER_02: -
U506 = 1701329: LM431 Adjustable Precision Zener Shunt Regulator; APPROVED_MANUFACTURER_02: Onsemi, NCP432BVSNT1G; APPROVED_MANUFACTURER_01: NATIONAL; PHYSICALPACKAGE: SOT23; MOUNTEDTYPE: SMD; Database Table Name: IC_PowerManagement; VALUE: LM431BIM3; TOLERANCE: -; PROTOTYPE_MPN_01: -; PROTOTYPE_MANUFACTURER_01: -; POWERRATING: -; PARTNUMBER: 1701329; PARTNAME: LM431BIM3; Library Name: AltiumLib_Database.DbLib; Component Kind: Standard; APPROVED_MPN_08: -; APPROVED_MPN_07: -; APPROVED_MPN_06: -; APPROVED_MPN_05: -; APPROVED_MPN_04: -; APPROVED_MPN_03: -; APPROVED_MPN_02: -; APPROVED_MPN_01: LM431BIM3; APPROVED_MANUFACTURER_08: -; APPROVED_MANUFACTURER_07: -; APPROVED_MANUFACTURER_06: -; APPROVED_MANUFACTURER_05: -; APPROVED_MANUFACTURER_04: -; APPROVED_MANUFACTURER_03: -
U530 = 1703721: Push-Pull Output Sub-Microamp Comparators; APPROVED_MANUFACTURER_01: Microchip Technology; PHYSICALPACKAGE: SOT23-5; MOUNTEDTYPE: SMD; Database Table Name: IC_Comparators; VALUE: MCP6541T-I/OT; TOLERANCE: -; PROTOTYPE_MPN_01: -; PROTOTYPE_MANUFACTURER_01: -; POWERRATING: -; PARTNUMBER: 1703721; PARTNAME: MCP6541T-I/OT; Library Name: AltiumLib_Database.DbLib; Component Kind: Standard; APPROVED_MPN_08: -; APPROVED_MPN_07: -; APPROVED_MPN_06: -; APPROVED_MPN_05: -; APPROVED_MPN_04: -; APPROVED_MPN_03: -; APPROVED_MPN_02: -; APPROVED_MPN_01: MCP6541T-I/OT; APPROVED_MANUFACTURER_08: -; APPROVED_MANUFACTURER_07: -; APPROVED_MANUFACTURER_06: -; APPROVED_MANUFACTURER_05: -; APPROVED_MANUFACTURER_04: -; APPROVED_MANUFACTURER_03: -; APPROVED_MANUFACTURER_02: -
VR501 = 1703394: Varistor Bidirectional ESD; APPROVED_MANUFACTURER_01: Comchip Technology; PHYSICALPACKAGE: EIA0603; MOUNTEDTYPE: SMD; Database Table Name: TVS_Diodes; VALUE: CPDUC5V0R-HF; TOLERANCE: -; PROTOTYPE_MPN_01: -; PROTOTYPE_MANUFACTURER_01: -; POWERRATING: -; PARTNUMBER: 1703394; PARTNAME: CPDUC5V0R-HF; Library Name: AltiumLib_Database.DbLib; Component Kind: Standard; APPROVED_MPN_08: -; APPROVED_MPN_07: -; APPROVED_MPN_06: -; APPROVED_MPN_05: -; APPROVED_MPN_04: -; APPROVED_MPN_03: -; APPROVED_MPN_02: -; APPROVED_MPN_01: CPDUC5V0R-HF; APPROVED_MANUFACTURER_08: -; APPROVED_MANUFACTURER_07: -; APPROVED_MANUFACTURER_06: -; APPROVED_MANUFACTURER_05: -; APPROVED_MANUFACTURER_04: -; APPROVED_MANUFACTURER_03: -; APPROVED_MANUFACTURER_02: -
